# Altium schematic sheet Main.SchDoc
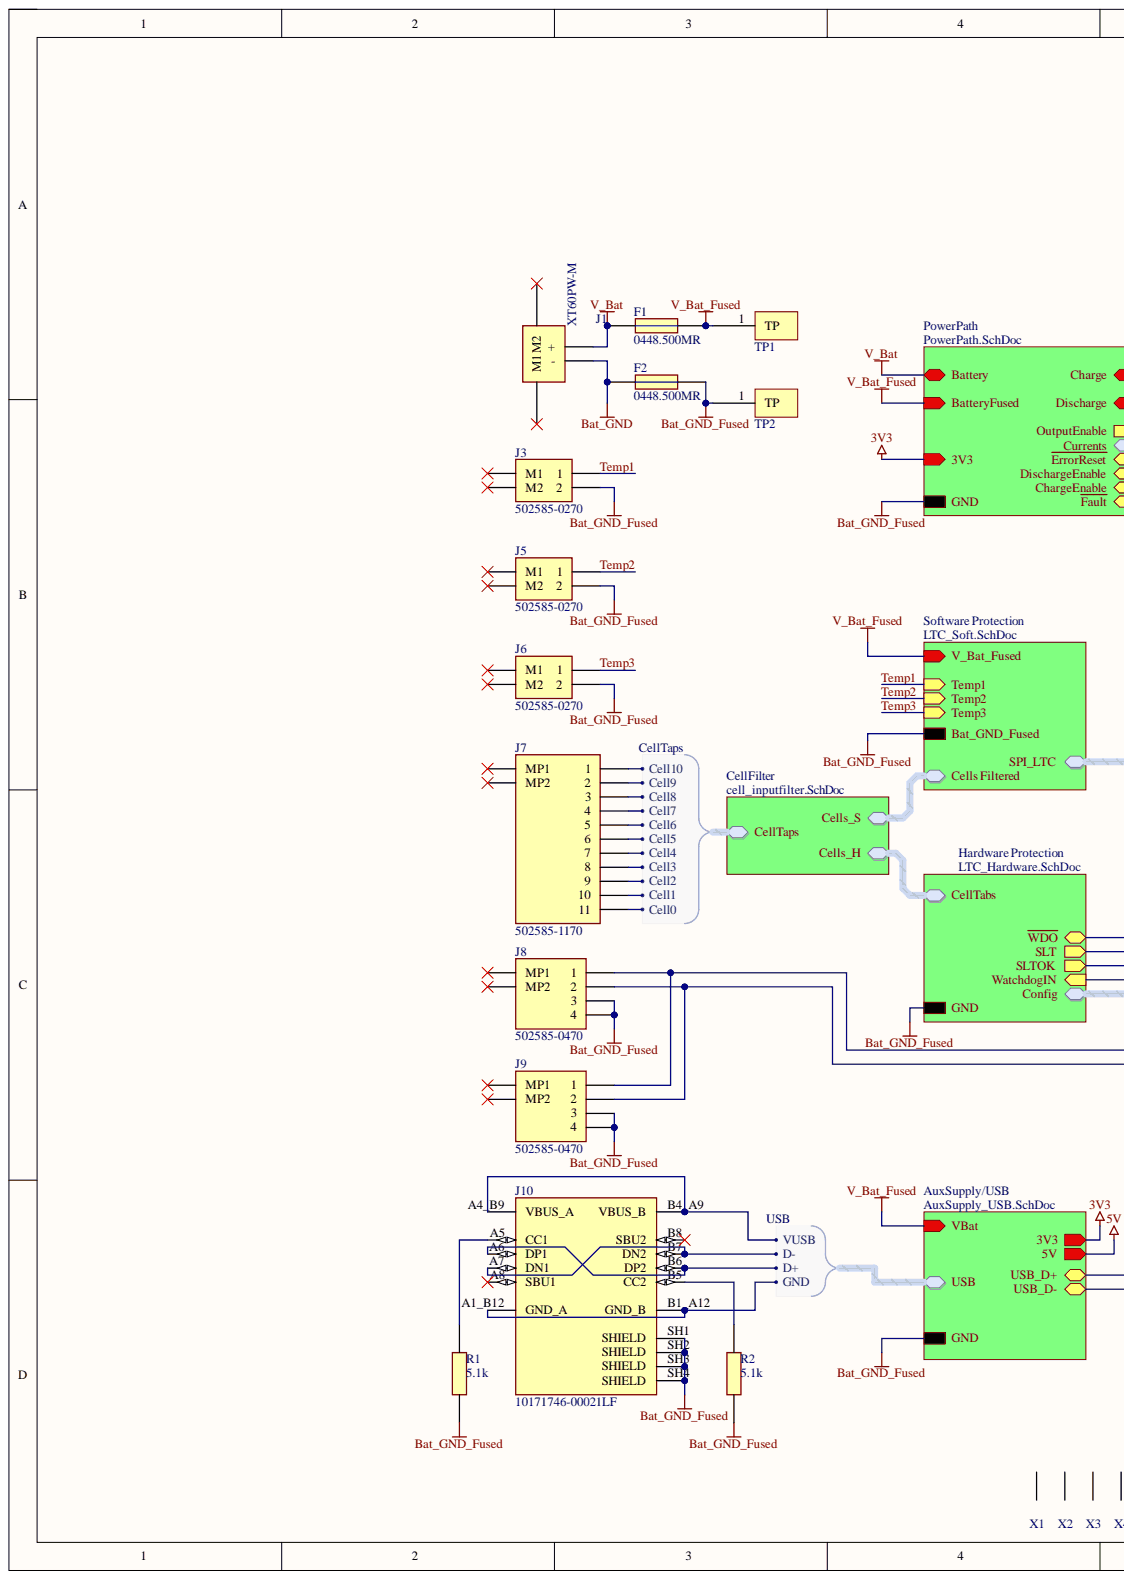
[diagram p0: Main.SchDoc, left]
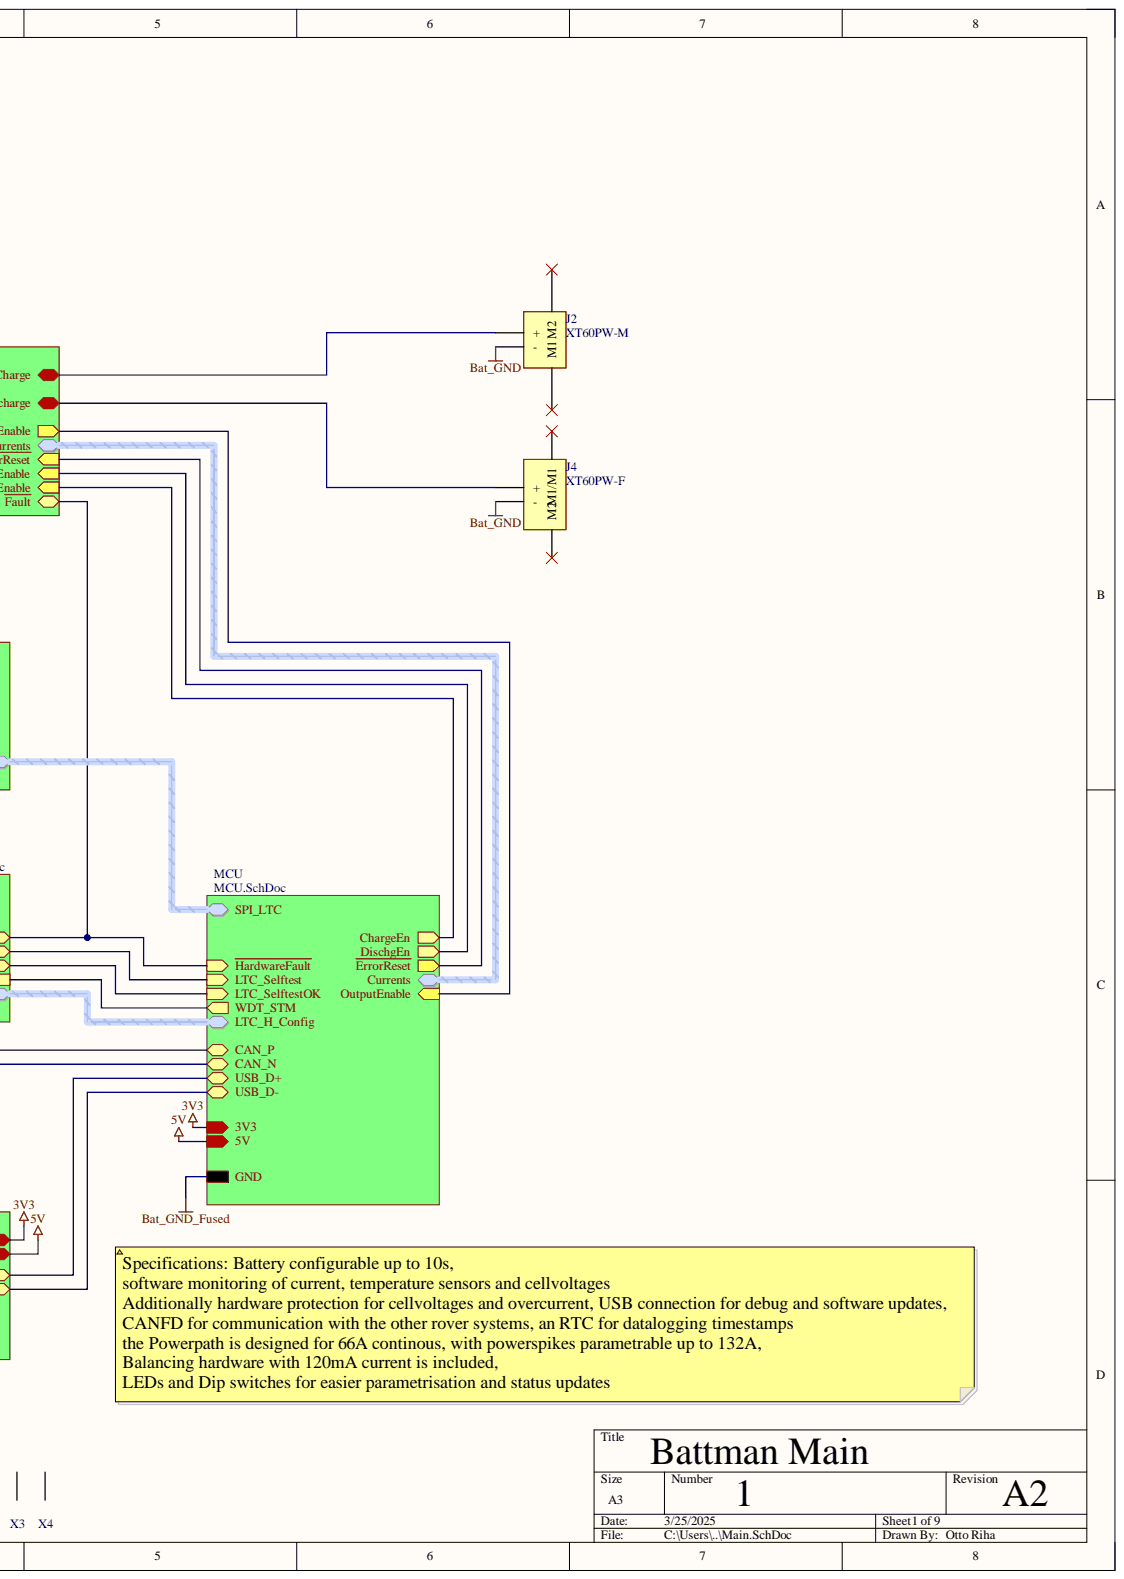
[diagram p1: Main.SchDoc, right]

## Parts
F1  0448.500MR  [FUSC6127X294N]
F2  0448.500MR  [FUSC6127X294N]
J1  XT60PW-M  [XT60PWM]
J2  XT60PW-M  [XT60PWM]
J3  502585-0270  [5025850270]
J4  XT60PW-F  [XT60PWF]
J5  502585-0270  [5025850270]
J6  502585-0270  [5025850270]
J7  502585-1170  [5025851170]
J8  502585-0470  [5025850470]
J9  502585-0470  [5025850470]
J10  10171746-00021LF  [AMPHENOL_10171746-00021LF]
R1  value 5.1k  [RESC1608X55N]  (hidden comment: CRCW06035K10FKEBC)
R2  value 5.1k  [RESC1608X55N]  (hidden comment: CRCW06035K10FKEBC)
TP1  Test Pad 1mm  [Test Pad 1mm-Footprint-1]  (value not drawn)
TP2  Test Pad 1mm  [Test Pad 1mm-Footprint-1]  (value not drawn)
X1  Trou pour Vis M3 celon DIN912  [VisM3]  (value not drawn)
X2  Trou pour Vis M3 celon DIN912  [VisM3]  (value not drawn)
X3  Trou pour Vis M3 celon DIN912  [VisM3]  (value not drawn)
X4  Trou pour Vis M3 celon DIN912  [VisM3]  (value not drawn)

## Nets
Bat_GND: F2.1 J1.1(-) J2.1(-) J4.2(-)
Bat_GND_Fused: F2.2 J3.2 J5.2 J6.2 J8.3 J8.4 J9.3 J9.4 J10.SH1(SHIELD) J10.SH2(SHIELD) J10.SH3(SHIELD) J10.SH4(SHIELD) R1.1 R2.1 TP2.1
V_Bat: F1.1 J1.2(+)
V_Bat_Fused: F1.2 TP1.1
Temp1: J3.1
Temp2: J5.1
Temp3: J6.1
NetJ1_M1: J1.M1
NetJ1_M2: J1.M2
NetJ2_2: J2.2(+)
NetJ2_M1: J2.M1
NetJ2_M2: J2.M2
NetJ3_M1: J3.M1
NetJ3_M2: J3.M2
NetJ4_1: J4.1(+)
NetJ4_3: J4.3(M1/M1)
NetJ4_4: J4.4(M2)
NetJ5_M1: J5.M1
NetJ5_M2: J5.M2
NetJ6_M1: J6.M1
NetJ6_M2: J6.M2
NetJ7_1: J7.1
NetJ7_2: J7.2
NetJ7_3: J7.3
NetJ7_4: J7.4
NetJ7_5: J7.5
NetJ7_6: J7.6
NetJ7_7: J7.7
NetJ7_8: J7.8
NetJ7_9: J7.9
NetJ7_10: J7.10
NetJ7_11: J7.11
NetJ7_MP1: J7.MP1
NetJ7_MP2: J7.MP2
NetJ8_1: J8.1 J9.1
NetJ8_2: J8.2 J9.2
NetJ8_MP1: J8.MP1
NetJ8_MP2: J8.MP2
NetJ9_MP1: J9.MP1
NetJ9_MP2: J9.MP2
NetJ10_A1_B12: J10.A1_B12(GND_A) J10.B1_A12(GND_B)
NetJ10_A4_B9: J10.A4_B9(VBUS_A) J10.B4_A9(VBUS_B)
NetJ10_A5: J10.A5(CC1) R1.2
NetJ10_A6: J10.A6(DP1) J10.B6(DP2)
NetJ10_A7: J10.A7(DN1) J10.B7(DN2)
NetJ10_A8: J10.A8(SBU1)
NetJ10_B5: J10.B5(CC2) R2.2
NetJ10_B8: J10.B8(SBU2)
NetX1_M1: X1.M1
NetX2_M1: X2.M1
NetX3_M1: X3.M1
NetX4_M1: X4.M1
3V3:
5V:
Cells Filtered.Cell0:
Cells Filtered.Cell1:
Cells Filtered.Cell2:
Cells Filtered.Cell3:
Cells Filtered.Cell4:
Cells Filtered.Cell5:
Cells Filtered.Cell6:
Cells Filtered.Cell7:
Cells Filtered.Cell8:
Cells Filtered.Cell9:
Cells Filtered.Cell10:
Cells Filtered.S1:
Cells Filtered.S2:
Cells Filtered.S3:
Cells Filtered.S4:
Cells Filtered.S5:
Cells Filtered.S6:
Cells Filtered.S7:
Cells Filtered.S8:
Cells Filtered.S9:
Cells Filtered.S10:
Cells_H.Cell0:
Cells_H.Cell1:
Cells_H.Cell2:
Cells_H.Cell3:
Cells_H.Cell4:
Cells_H.Cell5:
Cells_H.Cell6:
Cells_H.Cell7:
Cells_H.Cell8:
Cells_H.Cell9:
Cells_H.Cell10:
ChargeEn:
Config.A0_1:
Config.A0_2:
Config.A0_3:
Config.A0_4:
Config.A0_5:
Config.A0_6:
Config.A0_7:
Config.A0_8:
Config.A1_1:
Config.A1_2:
Config.A1_3:
Config.A1_4:
Config.A1_5:
Config.A1_6:
Config.A1_7:
Config.A1_8:
Currents.ChgCurrent:
Currents.ChgRef:
Currents.DischgCurrent:
Currents.DischgRef:
DischargeEnable:
~{ErrorReset}:
~{Fault}:
LTC_Selftest:
LTC_SelftestOK:
OutputEnable:
USB_D+:
USB_D-:
WatchdogIN:

## Library data of the parts
F1 F2 = 0448.500MR: Fuse; Manufacturer_Name: LITTELFUSE; Arrow Part Number: 0448.500MR; Arrow Price/Stock: https://www.arrow.com/en/products/0448.500mr/littelfuse; Component Kind: Standard; Datasheet Link: https://www.mouser.in/datasheet/2/240/Littelfuse_Fuse_448_Datasheet_pdf-970935.pdf; Height: 2.94mm; Library Name: SamacSys.SchLib; Manufacturer_Part_Number: 0448.500MR; Mouser Part Number: 576-0448.500MR; Mouser Price/Stock: https://www.mouser.co.uk/ProductDetail/Littelfuse/0448.500MR?qs=sba%2FvSn%252BnuOI9c6%252BQVFrGA%3D%3D
J1 J2 = XT60PW-M: Connector; Manufacturer_Name: Changzou Amass Elec; Component Kind: Standard; Datasheet Link: https://www.tme.eu/Document/9b8d0c5eb7094295f3d3112c214d3ade/XT60PW%20SPEC.pdf; Height: 8.4mm; Library Name: SamacSys.SchLib; Manufacturer_Part_Number: XT60PW-M
J3 J5 J6 = 502585-0270: Connector; Manufacturer_Name: Molex; Arrow Part Number: 502585-0270; Arrow Price/Stock: https://www.arrow.com/en/products/502585-0270/molex; Component Kind: Standard; Datasheet Link: https://www.molex.com/pdm_docs/sd/5025850270_sd.pdf; Height: 6.35mm; Library Name: SamacSys.SchLib; Manufacturer_Part_Number: 502585-0270; Mouser Part Number: 538-502585-0270; Mouser Price/Stock: https://www.mouser.co.uk/ProductDetail/Molex/502585-0270?qs=3OKVfsn1b5AIV0Cqykd0DA%3D%3D
J4 = XT60PW-F: Connector; Manufacturer_Name: Amass; Component Kind: Standard; Datasheet Link: https://www.tme.eu/Document/1191bc2fa3aee3c446e5a895fd8f7983/XT60PW-F.pdf; Height: 8.4mm; Library Name: SamacSys.SchLib; Manufacturer_Part_Number: XT60PW-F
J7 = 502585-1170: Connector; Manufacturer_Name: Molex; Manufacturer_Part_Number: 502585-1070; Arrow Part Number: 502585-1070; Arrow Price/Stock: https://www.arrow.com/en/products/502585-1070/molex; Component Kind: Standard; Datasheet Link: https://www.molex.com/pdm_docs/sd/5025851070_sd.pdf; Height: 6.35mm; Library Name: SamacSys.SchLib; Mouser Part Number: 538-502585-1070; Mouser Price/Stock: https://www.mouser.co.uk/ProductDetail/Molex/502585-1070?qs=3OKVfsn1b5BLfpTO0z92Dw%3D%3D
J8 J9 = 502585-0470: Connector; Manufacturer_Name: Molex; Arrow Part Number: 502585-0470; Arrow Price/Stock: https://www.arrow.com/en/products/5025850470/molex; Component Kind: Standard; Height: 6.6mm; Library Name: SamacSys.SchLib; Manufacturer_Part_Number: 502585-0470; Mouser Part Number: 538-502585-0470; Mouser Price/Stock: https://www.mouser.co.uk/ProductDetail/Molex/502585-0470?qs=3OKVfsn1b5AU8ip3wCejKg%3D%3D
J10 = 10171746-00021LF: USB-C (USB TYPE-C) USB 2.0 Receptacle Connector 24 (6+18 Dummy) Position Surface Mount, Right Angle; Through Hole; MF: Amphenol; Check_prices: https://www.snapeda.com/parts/10171746-00021LF/Amphenol/view-part/?ref=eda; Component Kind: Standard; Library Name: 10171746-00021LF.IntLib; MP: 10171746-00021LF; Package: None; SnapEDA_Link: https://www.snapeda.com/parts/10171746-00021LF/Amphenol/view-part/?ref=snap
R1 R2 = CRCW06035K10FKEBC: Resistor 5.1k, 1%; Manufacturer_Name: Vishay; Arrow Part Number: CRCW06035K10FKEBC; Arrow Price/Stock: https://www.arrow.com/en/products/crcw06035k10fkebc/vishay?region=nac; Component Kind: Standard; Datasheet Link: https://www.vishay.com/docs/28773/crcwce3.pdf; Height: 0.55mm; Library Name: SamacSys.SchLib; Manufacturer_Part_Number: CRCW06035K10FKEBC; Mouser Part Number: 71-CRCW06035K10FKEBC; Mouser Price/Stock: https://www.mouser.co.uk/ProductDetail/Vishay-Dale/CRCW06035K10FKEBC?qs=wd5RIQLrsJiajonkePuzqw%3D%3D; Supplier 1: Mouser; Supplier Part Number 1: 71-CRCW06035K10FKEBC
TP1 TP2 = CMP-002-00031-3: 1mm radius circuilar test pad; Component Kind: Standard; Library Name: EPFL Racing Team
X1-X4 = VisM3: Component Kind: Standard; Library Name: SamacSys.SchLib
